# Altium schematic sheet Connectors_1.SchDoc(Connectors_1)
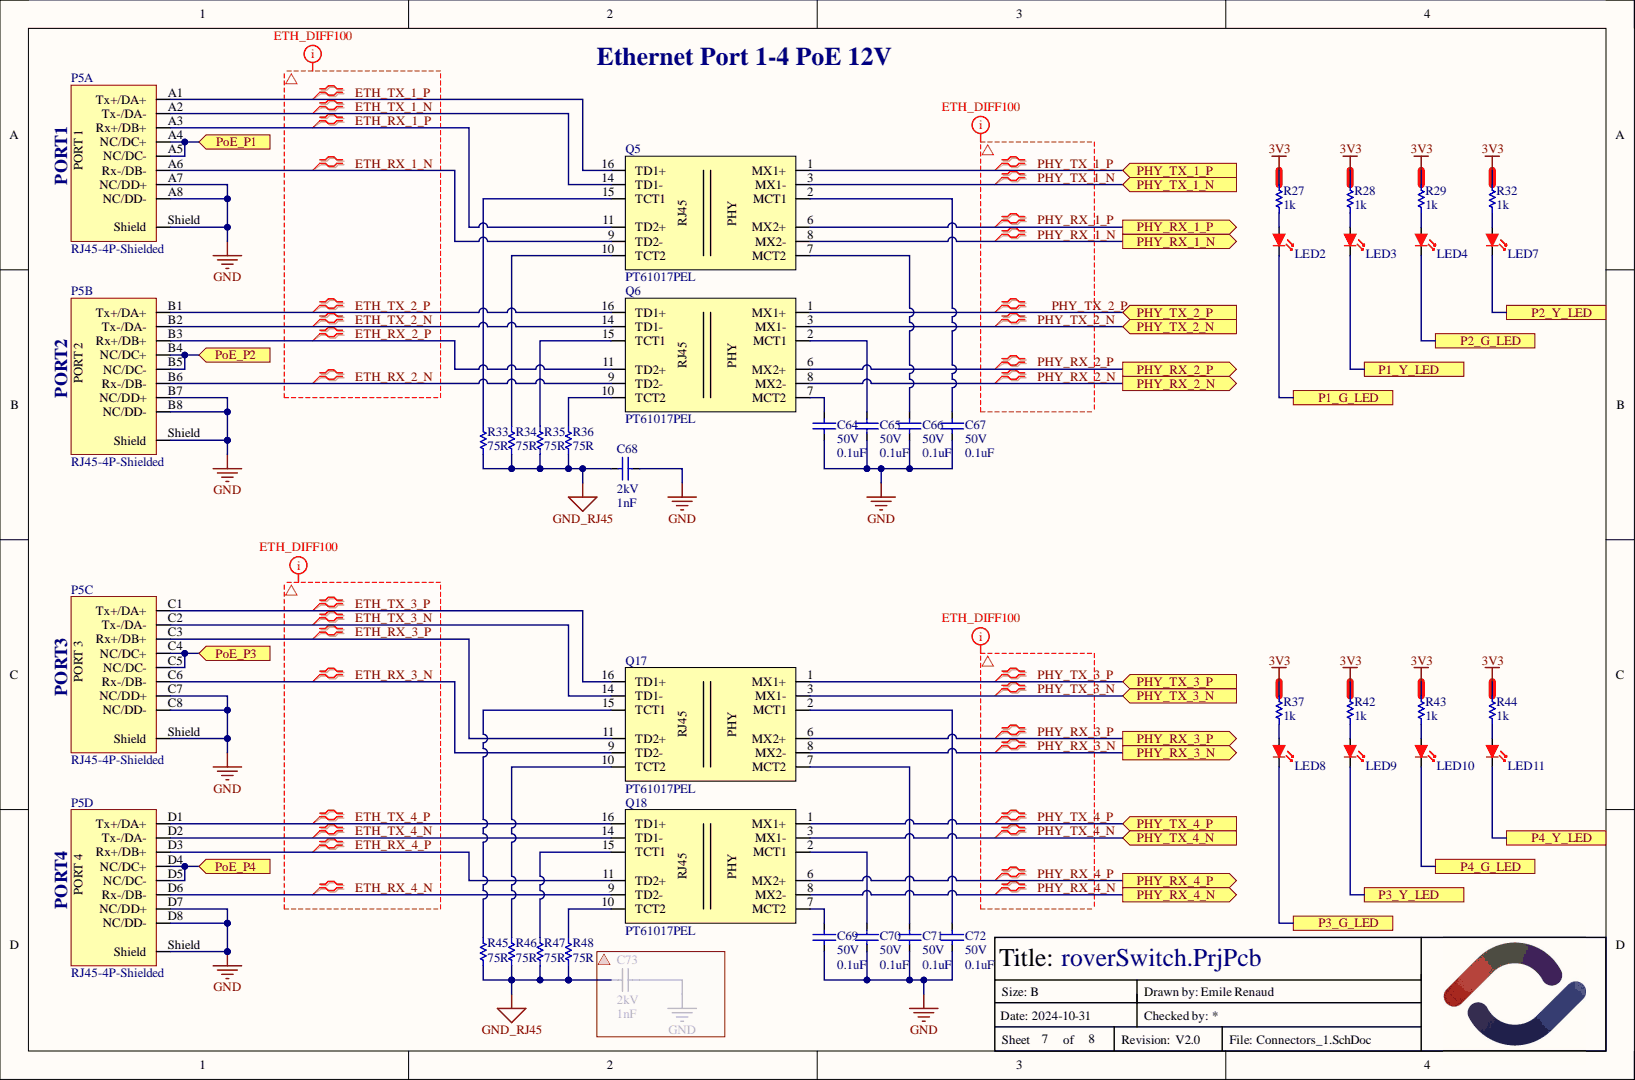
[diagram p0: Connectors_1.SchDoc]

## Parts
C64  value 0.1uF  Volt: 50V  [CAPACITOR-0603]  (hidden comment: Capacitor-0603-0.1uF-50V-X7R)
C65  value 0.1uF  Volt: 50V  [CAPACITOR-0603]  (hidden comment: Capacitor-0603-0.1uF-50V-X7R)
C66  value 0.1uF  Volt: 50V  [CAPACITOR-0603]  (hidden comment: Capacitor-0603-0.1uF-50V-X7R)
C67  value 0.1uF  Volt: 50V  [CAPACITOR-0603]  (hidden comment: Capacitor-0603-0.1uF-50V-X7R)
C68  value 1nF  Volt: 2kV  [CAPACITOR-1206]  (hidden comment: Capacitor-1206-1nF-2kV-X7R)
C69  value 0.1uF  Volt: 50V  [CAPACITOR-0603]  (hidden comment: Capacitor-0603-0.1uF-50V-X7R)
C70  value 0.1uF  Volt: 50V  [CAPACITOR-0603]  (hidden comment: Capacitor-0603-0.1uF-50V-X7R)
C71  value 0.1uF  Volt: 50V  [CAPACITOR-0603]  (hidden comment: Capacitor-0603-0.1uF-50V-X7R)
C72  value 0.1uF  Volt: 50V  [CAPACITOR-0603]  (hidden comment: Capacitor-0603-0.1uF-50V-X7R)
LED2  B1911USD-20D000114U1930  [LED-0603-2PIN]  (value not drawn)
LED3  B1911USD-20D000114U1930  [LED-0603-2PIN]  (value not drawn)
LED4  B1911USD-20D000114U1930  [LED-0603-2PIN]  (value not drawn)
LED7  B1911USD-20D000114U1930  [LED-0603-2PIN]  (value not drawn)
LED8  B1911USD-20D000114U1930  [LED-0603-2PIN]  (value not drawn)
LED9  B1911USD-20D000114U1930  [LED-0603-2PIN]  (value not drawn)
LED10  B1911USD-20D000114U1930  [LED-0603-2PIN]  (value not drawn)
LED11  B1911USD-20D000114U1930  [LED-0603-2PIN]  (value not drawn)
P5  RJ45-4P-Shielded  [ETH_A00-432-262-450]  (parts A,B,C,D)
Q5  PT61017PEL  [SOIC_PT61017PEL]
Q6  PT61017PEL  [SOIC_PT61017PEL]
Q17  PT61017PEL  [SOIC_PT61017PEL]
Q18  PT61017PEL  [SOIC_PT61017PEL]
R27  value 1k  [Resistor-0603]  (hidden comment: SMT resistor)
R28  value 1k  [Resistor-0603]  (hidden comment: SMT resistor)
R29  value 1k  [Resistor-0603]  (hidden comment: SMT resistor)
R32  value 1k  [Resistor-0603]  (hidden comment: SMT resistor)
R33  value 75R  [Resistor-0603]  (hidden comment: SMT resistor)
R34  value 75R  [Resistor-0603]  (hidden comment: SMT resistor)
R35  value 75R  [Resistor-0603]  (hidden comment: SMT resistor)
R36  value 75R  [Resistor-0603]  (hidden comment: SMT resistor)
R37  value 1k  [Resistor-0603]  (hidden comment: SMT resistor)
R42  value 1k  [Resistor-0603]  (hidden comment: SMT resistor)
R43  value 1k  [Resistor-0603]  (hidden comment: SMT resistor)
R44  value 1k  [Resistor-0603]  (hidden comment: SMT resistor)
R45  value 75R  [Resistor-0603]  (hidden comment: SMT resistor)
R46  value 75R  [Resistor-0603]  (hidden comment: SMT resistor)
R47  value 75R  [Resistor-0603]  (hidden comment: SMT resistor)
R48  value 75R  [Resistor-0603]  (hidden comment: SMT resistor)

## Ports
P1_G_LED, P1_Y_LED, P2_G_LED, P2_Y_LED, P3_G_LED, P3_Y_LED, P4_G_LED, P4_Y_LED, PHY_RX_1_N, PHY_RX_1_P, PHY_RX_2_N, PHY_RX_2_P, PHY_RX_3_N, PHY_RX_3_P, PHY_RX_4_N, PHY_RX_4_P, PHY_TX_1_N, PHY_TX_1_P, PHY_TX_2_N, PHY_TX_2_P, PHY_TX_3_N, PHY_TX_3_P, PHY_TX_4_N, PHY_TX_4_P, PoE_P1, PoE_P2, PoE_P3, PoE_P4

## Nets
3V3: R27.2 R28.2 R29.2 R32.2 R37.2 R42.2 R43.2 R44.2
GND: C64.1 C65.1 C66.1 C67.1 C68.2 C69.1 C70.1 C71.1 C72.1 P5.A7(NC/DD+) P5.A8(NC/DD-) P5.B7(NC/DD+) P5.B8(NC/DD-) P5.C7(NC/DD+) P5.C8(NC/DD-) P5.D7(NC/DD+) P5.D8(NC/DD-) P5.Shield
GND_RJ45: C68.1 R33.1 R34.1 R35.1 R36.1 R45.1 R46.1 R47.1 R48.1
ETH_RX_1_N: P5.A6(Rx-/DB-) Q5.9(TD2-)
ETH_RX_1_P: P5.A3(Rx+/DB+) Q5.11(TD2+)
ETH_RX_2_N: P5.B6(Rx-/DB-) Q6.9(TD2-)
ETH_RX_2_P: P5.B3(Rx+/DB+) Q6.11(TD2+)
ETH_RX_3_N: P5.C6(Rx-/DB-) Q17.9(TD2-)
ETH_RX_3_P: P5.C3(Rx+/DB+) Q17.11(TD2+)
ETH_RX_4_N: P5.D6(Rx-/DB-) Q18.9(TD2-)
ETH_RX_4_P: P5.D3(Rx+/DB+) Q18.11(TD2+)
ETH_TX_1_N: P5.A2(Tx-/DA-) Q5.14(TD1-)
ETH_TX_1_P: P5.A1(Tx+/DA+) Q5.16(TD1+)
ETH_TX_2_N: P5.B2(Tx-/DA-) Q6.14(TD1-)
ETH_TX_2_P: P5.B1(Tx+/DA+) Q6.16(TD1+)
ETH_TX_3_N: P5.C2(Tx-/DA-) Q17.14(TD1-)
ETH_TX_3_P: P5.C1(Tx+/DA+) Q17.16(TD1+)
ETH_TX_4_N: P5.D2(Tx-/DA-) Q18.14(TD1-)
ETH_TX_4_P: P5.D1(Tx+/DA+) Q18.16(TD1+)
P1_G_LED: LED2.1 ; port P1_G_LED
P1_Y_LED: LED3.1 ; port P1_Y_LED
P2_G_LED: LED4.1 ; port P2_G_LED
P2_Y_LED: LED7.1 ; port P2_Y_LED
P3_G_LED: LED8.1 ; port P3_G_LED
P3_Y_LED: LED9.1 ; port P3_Y_LED
P4_G_LED: LED10.1 ; port P4_G_LED
P4_Y_LED: LED11.1 ; port P4_Y_LED
PHY_RX_1_N: Q5.8(MX2-) ; port PHY_RX_1_N
PHY_RX_1_P: Q5.6(MX2+) ; port PHY_RX_1_P
PHY_RX_2_N: Q6.8(MX2-) ; port PHY_RX_2_N
PHY_RX_2_P: Q6.6(MX2+) ; port PHY_RX_2_P
PHY_RX_3_N: Q17.8(MX2-) ; port PHY_RX_3_N
PHY_RX_3_P: Q17.6(MX2+) ; port PHY_RX_3_P
PHY_RX_4_N: Q18.8(MX2-) ; port PHY_RX_4_N
PHY_RX_4_P: Q18.6(MX2+) ; port PHY_RX_4_P
PHY_TX_1_N: Q5.3(MX1-) ; port PHY_TX_1_N
PHY_TX_1_P: Q5.1(MX1+) ; port PHY_TX_1_P
PHY_TX_2_N: Q6.3(MX1-) ; port PHY_TX_2_N
PHY_TX_2_P: Q6.1(MX1+) ; port PHY_TX_2_P
PHY_TX_3_N: Q17.3(MX1-) ; port PHY_TX_3_N
PHY_TX_3_P: Q17.1(MX1+) ; port PHY_TX_3_P
PHY_TX_4_N: Q18.3(MX1-) ; port PHY_TX_4_N
PHY_TX_4_P: Q18.1(MX1+) ; port PHY_TX_4_P
PoE_P1: P5.A4(NC/DC+) P5.A5(NC/DC-) ; port PoE_P1
PoE_P2: P5.B4(NC/DC+) P5.B5(NC/DC-) ; port PoE_P2
PoE_P3: P5.C4(NC/DC+) P5.C5(NC/DC-) ; port PoE_P3
PoE_P4: P5.D4(NC/DC+) P5.D5(NC/DC-) ; port PoE_P4
NetC64_2: C64.2 Q6.7(MCT2)
NetC65_2: C65.2 Q6.2(MCT1)
NetC66_2: C66.2 Q5.7(MCT2)
NetC67_2: C67.2 Q5.2(MCT1)
NetC69_2: C69.2 Q18.7(MCT2)
NetC70_2: C70.2 Q18.2(MCT1)
NetC71_2: C71.2 Q17.7(MCT2)
NetC72_2: C72.2 Q17.2(MCT1)
NetLED2_2: LED2.2 R27.1
NetLED3_2: LED3.2 R28.1
NetLED4_2: LED4.2 R29.1
NetLED7_2: LED7.2 R32.1
NetLED8_2: LED8.2 R37.1
NetLED9_2: LED9.2 R42.1
NetLED10_2: LED10.2 R43.1
NetLED11_2: LED11.2 R44.1
NetQ5_10: Q5.10(TCT2) R34.2
NetQ5_15: Q5.15(TCT1) R33.2
NetQ6_10: Q6.10(TCT2) R36.2
NetQ6_15: Q6.15(TCT1) R35.2
NetQ17_10: Q17.10(TCT2) R46.2
NetQ17_15: Q17.15(TCT1) R45.2
NetQ18_10: Q18.10(TCT2) R48.2
NetQ18_15: Q18.15(TCT1) R47.2

## Library data of the parts
C64-C67 C69-C72 = Capacitor-0603-0.1uF-50V-X7R: Precision: X7R-10%; Component Kind: Standard; Description: Capacitor-0603-0.1uF-50V-X7R; Library Name: Capacitors.SchLib; Mounted: Yes; Package / Case: Metric 1608, EIA 0603; Supplier 1: Digi-Key; Supplier 2: Digi-Key; Supplier Part Number 1: 1276-1935-2-ND; Supplier Part Number 2: 1276-1934-2-ND
C68 = Capacitor-1206-1nF-2kV-X7R: Precision: X7R-10%; Component Kind: Standard; Description: Capacitor-1206-1nF-2kV-X7R; Library Name: Capacitors.SchLib; Mounted: Yes; Package / Case: Metric 3216, EIA 1206; Supplier 1: Digi-Key; Supplier 2: Digi-Key; Supplier Part Number 1: 399-C1206C102KGRAC7800CT-ND
LED2-LED4 LED7-LED11 = B1911USD-20D000114U1930: LED RED CLEAR 0603 SMD; Component Kind: Standard; Library Name: LEDs.SchLib; Mounted: Yes; Package / Case: 0603 (1608 Metric); Supplier 1: Digi-Key; Supplier Part Number 1: 3147-B1911USD-20D000114U1930CT-ND
P5 = RJ45-4Ports: Jack Modular Connector 8p8c (RJ45, Ethernet) 90° Angle (Right) Shielded Cat5; Component Kind: Standard; HelpURL: https://mm.digikey.com/Volume0/opasdata/d220001/medias/docus/971/A00-432-262-450.pdf; Library Name: PC-connector.SchLib; Mounted: Yes; Package / Case: TH; Supplier 1: Digikey; Supplier Part Number 1: 151-1120-ND; Volt: N/A
Q5 Q6 Q17 Q18 = PT61017PEL: 350µH LAN 10/100 Base-T Pulse Transformer 1CT:1CT Surface Mount; Component Kind: Standard; Mounted: Yes; Supplier 1: Digi-Key; Supplier Part Number 1: PT61017PELCT-ND; Library Name: Transformers-Magnetics.SchLib
R27-R29 R32 R37 R42-R44 = Resistor-0603-1k: Resistor0603-1k; Precision: 1%; Watt: 0.1W; Component Kind: Standard; Library Name: Resistors.SchLib; Mounted: Yes; Package / Case: Metric 1608, EIA 0603; Supplier 1: Digi-Key; Supplier Part Number 1: 311-1.00KHRCT-ND
R33-R36 R45-R48 = Resistor-0603-75R: Resistor0603-75R; Precision: 1%; Watt: 0.1W; Component Kind: Standard; Library Name: Resistors.SchLib; Mounted: Yes; Package / Case: Metric 1608, EIA 0603; Supplier 1: Digi-Key; Supplier Part Number 1: 311-75.0HRCT-ND
